# Altium schematic sheet 04_ WSTK Connectors & Board ID.SchDoc
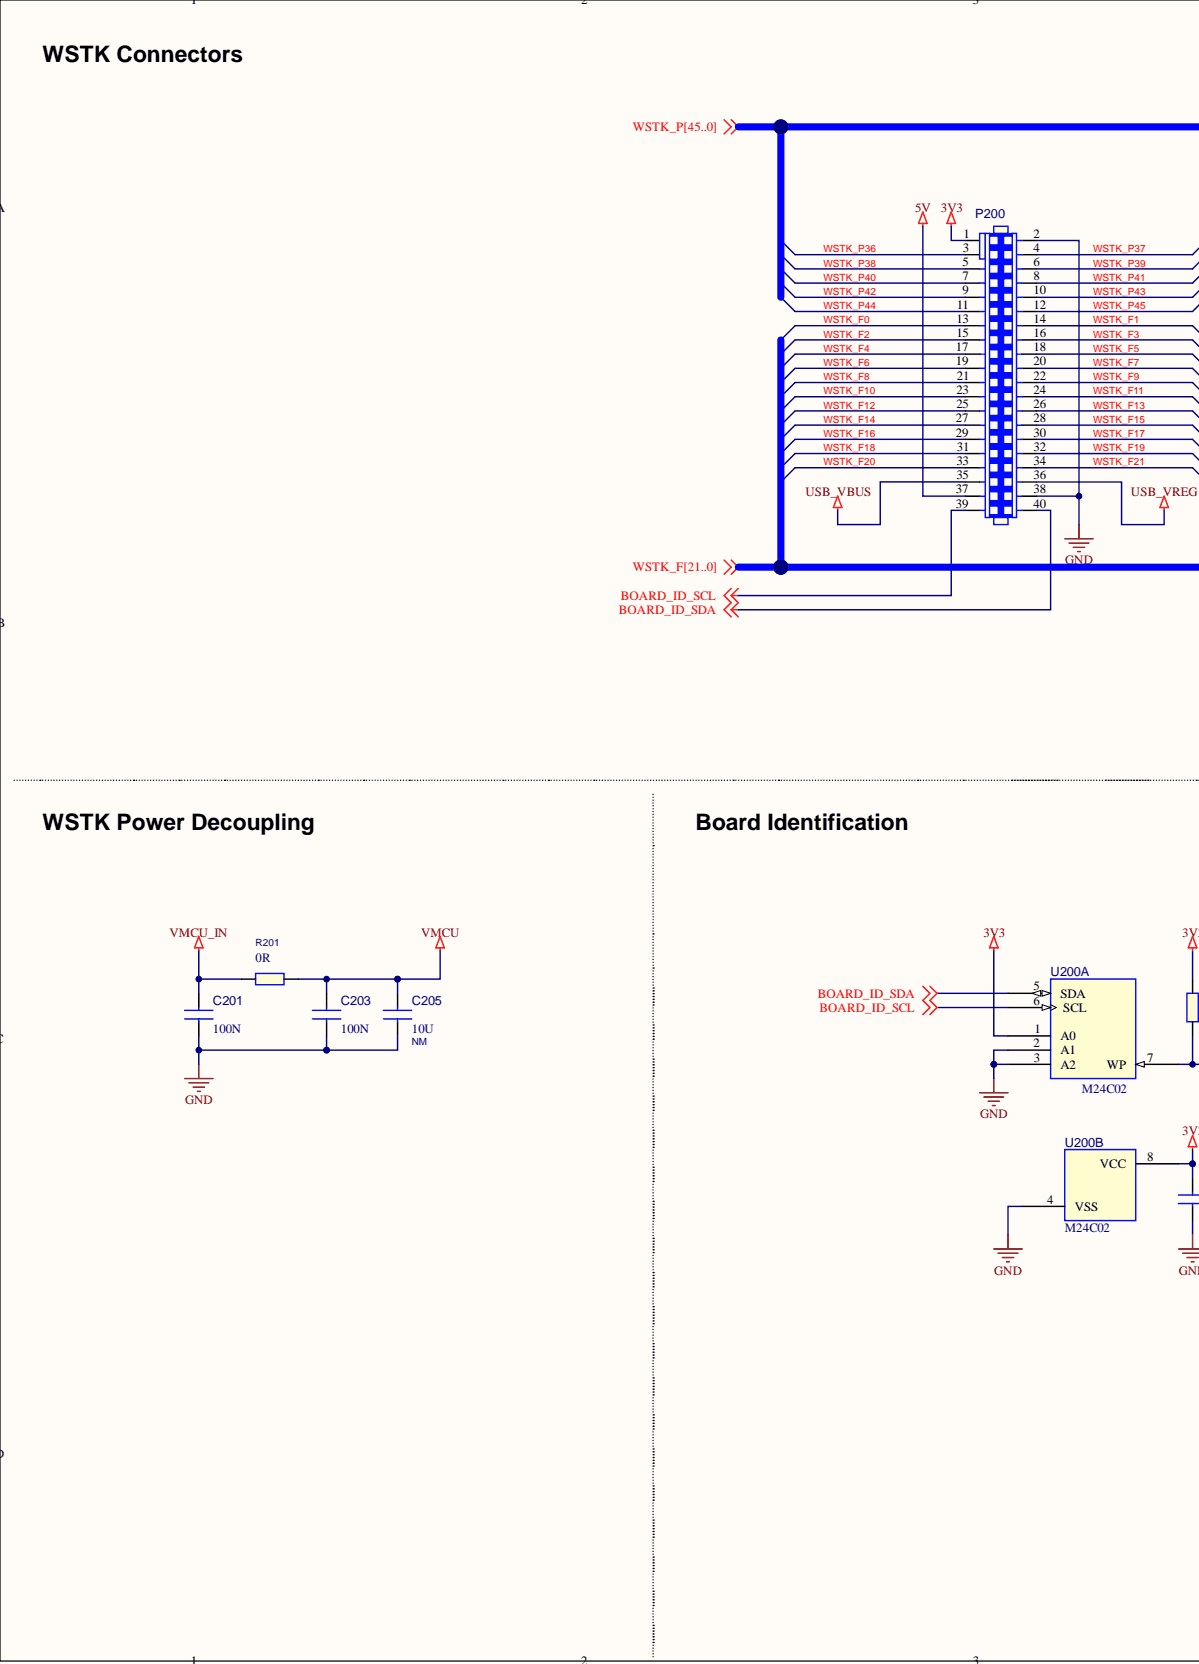
[diagram p0: 04_ WSTK Connectors & Board ID.SchDoc, left]
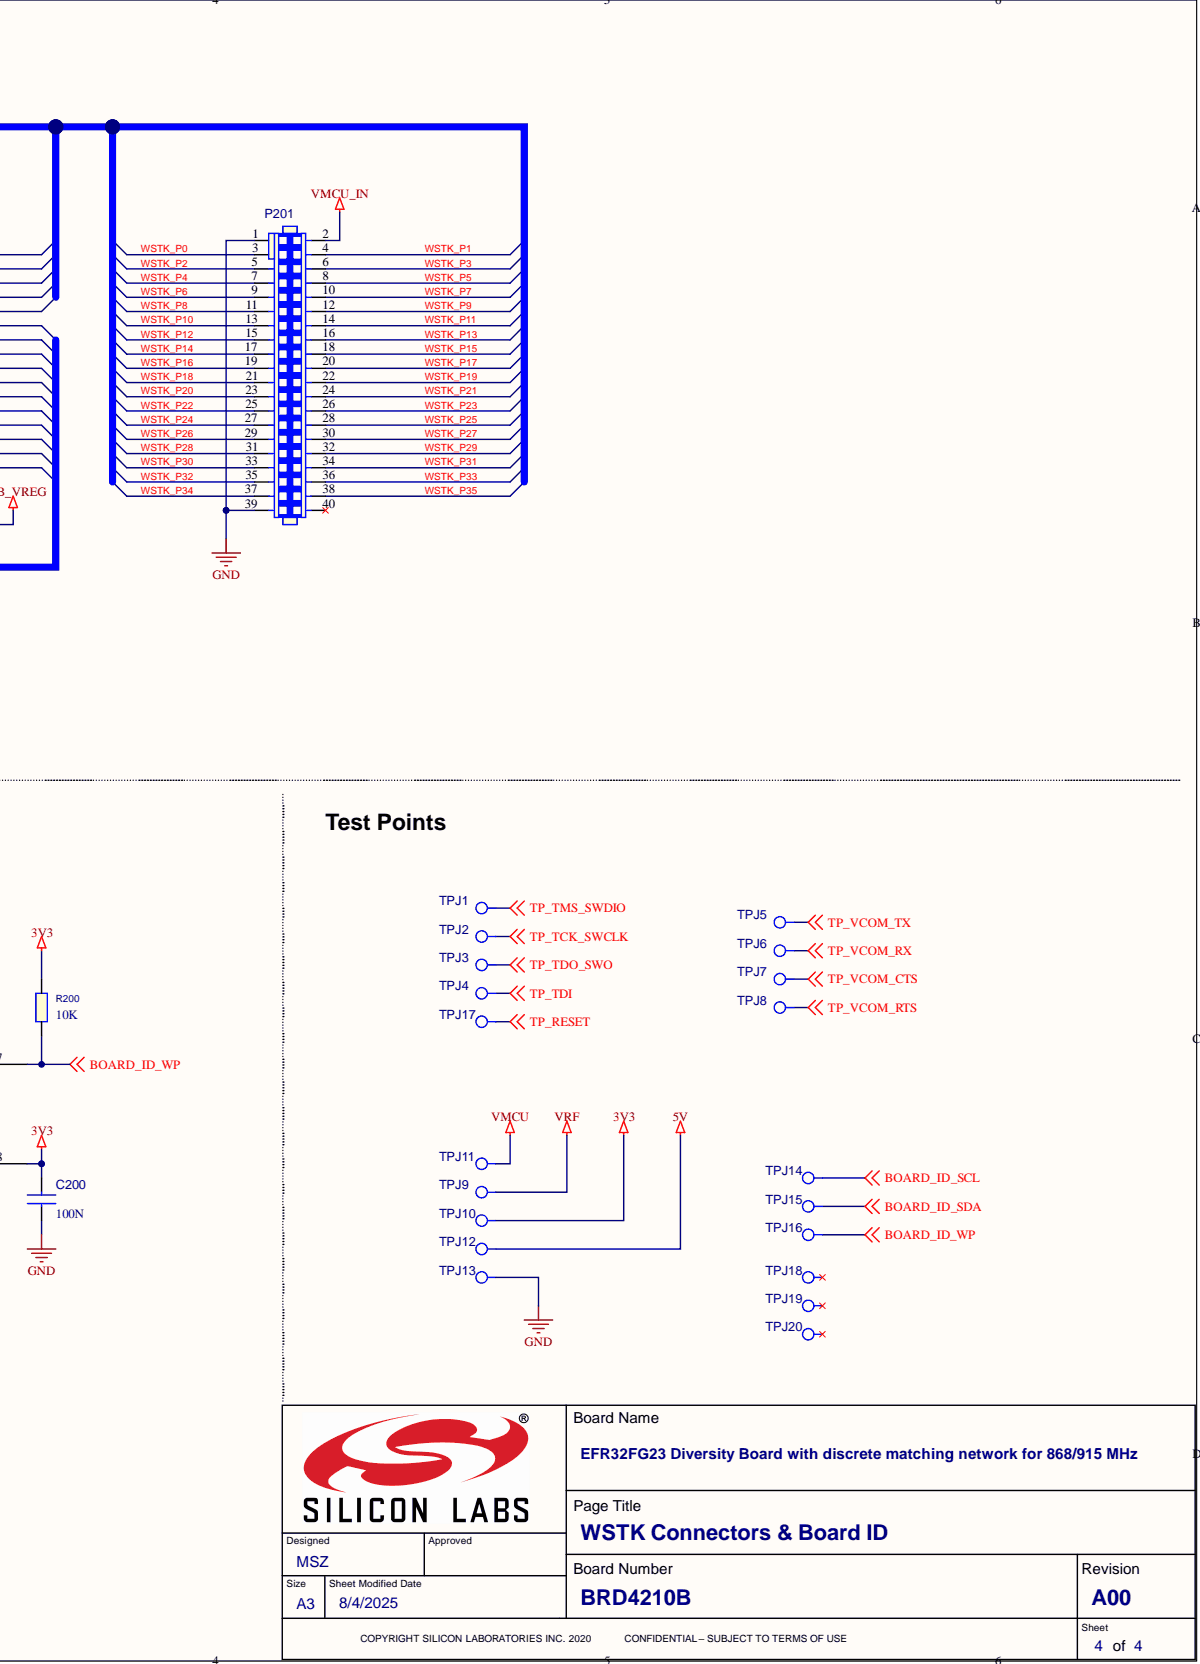
[diagram p1: 04_ WSTK Connectors & Board ID.SchDoc, right]

## Parts
C200  100N  Part Reference: C200  [C0201_L]
C201  100N  Part Reference: C201  [C0201_L]
C203  100N  Part Reference: C203  [C0201_L]
C205  10U  Not Mounted: NM  Part Reference: C205  [T_UNDEF]
P200  Part Reference: P200  [SAM_SFC-120-T2-D-A-2X20_SNUM]  (hidden comment: SOCKET_WCON_2X20_1.27MM_SMD)
P201  Part Reference: P201  [SAM_SFC-120-T2-D-A-2X20_SNUM]  (hidden comment: SOCKET_WCON_2X20_1.27MM_SMD)
R200  10K  Part Reference: R200  [R0201_L]
R201  0R  Part Reference: R201  [T_UNDEF]
TPJ1  Part Reference: TPJ1  [TP_1.0_SMD]  (hidden comment: TPJ_SMD)
TPJ2  Part Reference: TPJ2  [TP_1.0_SMD]  (hidden comment: TPJ_SMD)
TPJ3  Part Reference: TPJ3  [TP_1.0_SMD]  (hidden comment: TPJ_SMD)
TPJ4  Part Reference: TPJ4  [TP_1.0_SMD]  (hidden comment: TPJ_SMD)
TPJ5  Part Reference: TPJ5  [TP_1.0_SMD]  (hidden comment: TPJ_SMD)
TPJ6  Part Reference: TPJ6  [TP_1.0_SMD]  (hidden comment: TPJ_SMD)
TPJ7  Part Reference: TPJ7  [TP_1.0_SMD]  (hidden comment: TPJ_SMD)
TPJ8  Part Reference: TPJ8  [TP_1.0_SMD]  (hidden comment: TPJ_SMD)
TPJ9  Part Reference: TPJ9  [TP1MM_SMD]  (hidden comment: TPJ_SMD)
TPJ10  Part Reference: TPJ10  [TP1MM_SMD]  (hidden comment: TPJ_SMD)
TPJ11  Part Reference: TPJ11  [TP1MM_SMD]  (hidden comment: TPJ_SMD)
TPJ12  Part Reference: TPJ12  [TP1MM_SMD]  (hidden comment: TPJ_SMD)
TPJ13  Part Reference: TPJ13  [TP1MM_SMD]  (hidden comment: TPJ_SMD)
TPJ14  Part Reference: TPJ14  [TP1MM_SMD]  (hidden comment: TPJ_SMD)
TPJ15  Part Reference: TPJ15  [TP1MM_SMD]  (hidden comment: TPJ_SMD)
TPJ16  Part Reference: TPJ16  [TP1MM_SMD]  (hidden comment: TPJ_SMD)
TPJ17  Part Reference: TPJ17  [TP_1.0_SMD]  (hidden comment: TPJ_SMD)
TPJ18  Part Reference: TPJ18  [TP1MM_SMD]  (hidden comment: TPJ_SMD)
TPJ19  Part Reference: TPJ19  [TP1MM_SMD]  (hidden comment: TPJ_SMD)
TPJ20  Part Reference: TPJ20  [TP1MM_SMD]  (hidden comment: TPJ_SMD)
U200  M24C02  [TDFN8_M050_2.0X3.0_EP]  (parts A,B)

## Nets
3V3: C200.1 P200.1 R200.2 TPJ10.1 U200.1(A0) U200.8(VCC)
5V: P200.37 TPJ12.1
BOARD_ID_SCL: P200.39 TPJ14.1 U200.6(SCL)
BOARD_ID_SDA: P200.40 TPJ15.1 U200.5(SDA)
BOARD_ID_WP: R200.1 TPJ16.1 U200.7(WP)
GND: C200.2 C201.2 C203.2 C205.2 P200.2 P200.38 P201.1 P201.39 TPJ13.1 U200.2(A1) U200.3(A2) U200.4(VSS)
TP_RESET: TPJ17.1
TP_TCK_SWCLK: TPJ2.1
TP_TDI: TPJ4.1
TP_TDO_SWO: TPJ3.1
TP_TMS_SWDIO: TPJ1.1
TP_VCOM_CTS: TPJ7.1
TP_VCOM_RTS: TPJ8.1
TP_VCOM_RX: TPJ6.1
TP_VCOM_TX: TPJ5.1
USB_VBUS: P200.35
USB_VREG: P200.36
VMCU: C203.1 C205.1 R201.2 TPJ11.1
VMCU_IN: C201.1 P201.2 R201.1
VRF: TPJ9.1
WSTK_F0: P200.13  [also labelled ]
WSTK_F1: P200.14  [also labelled ]
WSTK_F2: P200.15  [also labelled ]
WSTK_F3: P200.16  [also labelled ]
WSTK_F4: P200.17  [also labelled ]
WSTK_F5: P200.18  [also labelled ]
WSTK_F6: P200.19  [also labelled ]
WSTK_F7: P200.20  [also labelled ]
WSTK_F8: P200.21  [also labelled ]
WSTK_F9: P200.22  [also labelled ]
WSTK_F10: P200.23  [also labelled ]
WSTK_F11: P200.24  [also labelled ]
WSTK_F12: P200.25  [also labelled ]
WSTK_F13: P200.26  [also labelled ]
WSTK_F14: P200.27  [also labelled ]
WSTK_F15: P200.28  [also labelled ]
WSTK_F16: P200.29  [also labelled ]
WSTK_F17: P200.30  [also labelled ]
WSTK_F18: P200.31  [also labelled ]
WSTK_F19: P200.32  [also labelled ]
WSTK_F20: P200.33  [also labelled ]
WSTK_F21: P200.34  [also labelled ]
WSTK_P0: P201.3  [also labelled ]
WSTK_P1: P201.4  [also labelled ]
WSTK_P2: P201.5  [also labelled ]
WSTK_P3: P201.6  [also labelled ]
WSTK_P4: P201.7  [also labelled ]
WSTK_P5: P201.8  [also labelled ]
WSTK_P6: P201.9  [also labelled ]
WSTK_P7: P201.10  [also labelled ]
WSTK_P8: P201.11  [also labelled ]
WSTK_P9: P201.12  [also labelled ]
WSTK_P10: P201.13  [also labelled ]
WSTK_P11: P201.14  [also labelled ]
WSTK_P12: P201.15  [also labelled ]
WSTK_P13: P201.16  [also labelled ]
WSTK_P14: P201.17  [also labelled ]
WSTK_P15: P201.18  [also labelled ]
WSTK_P16: P201.19  [also labelled ]
WSTK_P17: P201.20  [also labelled ]
WSTK_P18: P201.21  [also labelled ]
WSTK_P19: P201.22  [also labelled ]
WSTK_P20: P201.23  [also labelled ]
WSTK_P21: P201.24  [also labelled ]
WSTK_P22: P201.25  [also labelled ]
WSTK_P23: P201.26  [also labelled ]
WSTK_P24: P201.27  [also labelled ]
WSTK_P25: P201.28  [also labelled ]
WSTK_P26: P201.29  [also labelled ]
WSTK_P27: P201.30  [also labelled ]
WSTK_P28: P201.31  [also labelled ]
WSTK_P29: P201.32  [also labelled ]
WSTK_P30: P201.33  [also labelled ]
WSTK_P31: P201.34  [also labelled ]
WSTK_P32: P201.35  [also labelled ]
WSTK_P33: P201.36  [also labelled ]
WSTK_P34: P201.37  [also labelled ]
WSTK_P35: P201.38  [also labelled ]
WSTK_P36: P200.3  [also labelled ]
WSTK_P37: P200.4  [also labelled ]
WSTK_P38: P200.5  [also labelled ]
WSTK_P39: P200.6  [also labelled ]
WSTK_P40: P200.7  [also labelled ]
WSTK_P41: P200.8  [also labelled ]
WSTK_P42: P200.9  [also labelled ]
WSTK_P43: P200.10  [also labelled ]
WSTK_P44: P200.11  [also labelled ]
WSTK_P45: P200.12  [also labelled ]

Not connected: P201 40; TPJ18 1; TPJ19 1; TPJ20 1

## Library data of the parts
C200 C201 C203 = C0201: Rating: 16V; Tolerance: 20%; Component Kind: Standard; PCB Footprint: C0201_L; Technology: X7S; Value: 100N
C205 = CAPACITOR: PCB Footprint: C0805_L; Component Kind: Standard; Technology: X5R; Value: 10U
P200 P201 = SOCKET_WCON_2X20_1.27MM_SMD: Mfg: WCON; Mfg #: 2344-220MS3CUNR6; Component Kind: Standard; PCB Footprint: SAM_SFC-120-T2-D-A-2X20_SNUM; Price_1K: 3.44; Value: SOCKET_WCON_2X20_1.27MM_SMD
R200 = R0201: Component Kind: Standard; PCB Footprint: R0201_L; Price_1K: 0.005; Value: 10K
R201 = RESISTOR: PCB Footprint: R0402_L; Component Kind: Standard; Price_1K: 0.005; Value: 0R
TPJ1-TPJ8 TPJ17 = TPJ_SMD_Dup1: Component Kind: Standard; PCB Footprint: TP_1.0_SMD
TPJ9-TPJ16 TPJ18-TPJ20 = TPJ_SMD: PCB Footprint: TP_1.0_SMD; Component Kind: Standard
U200 = M24C02: Part Reference: U200; CONTEC Footprint: MSOP8_M065_W3.0; Mfg: ST Microelectronics; Mfg #: M24C02-FMC6TG; Component Kind: Standard; PCB Footprint: TDFN8_M050_2.0X3.0_EP; Price_1K: 0.06; Value: M24C02
